# Altium schematic sheet Bluetooth+WiFi+LoRa.SchDoc
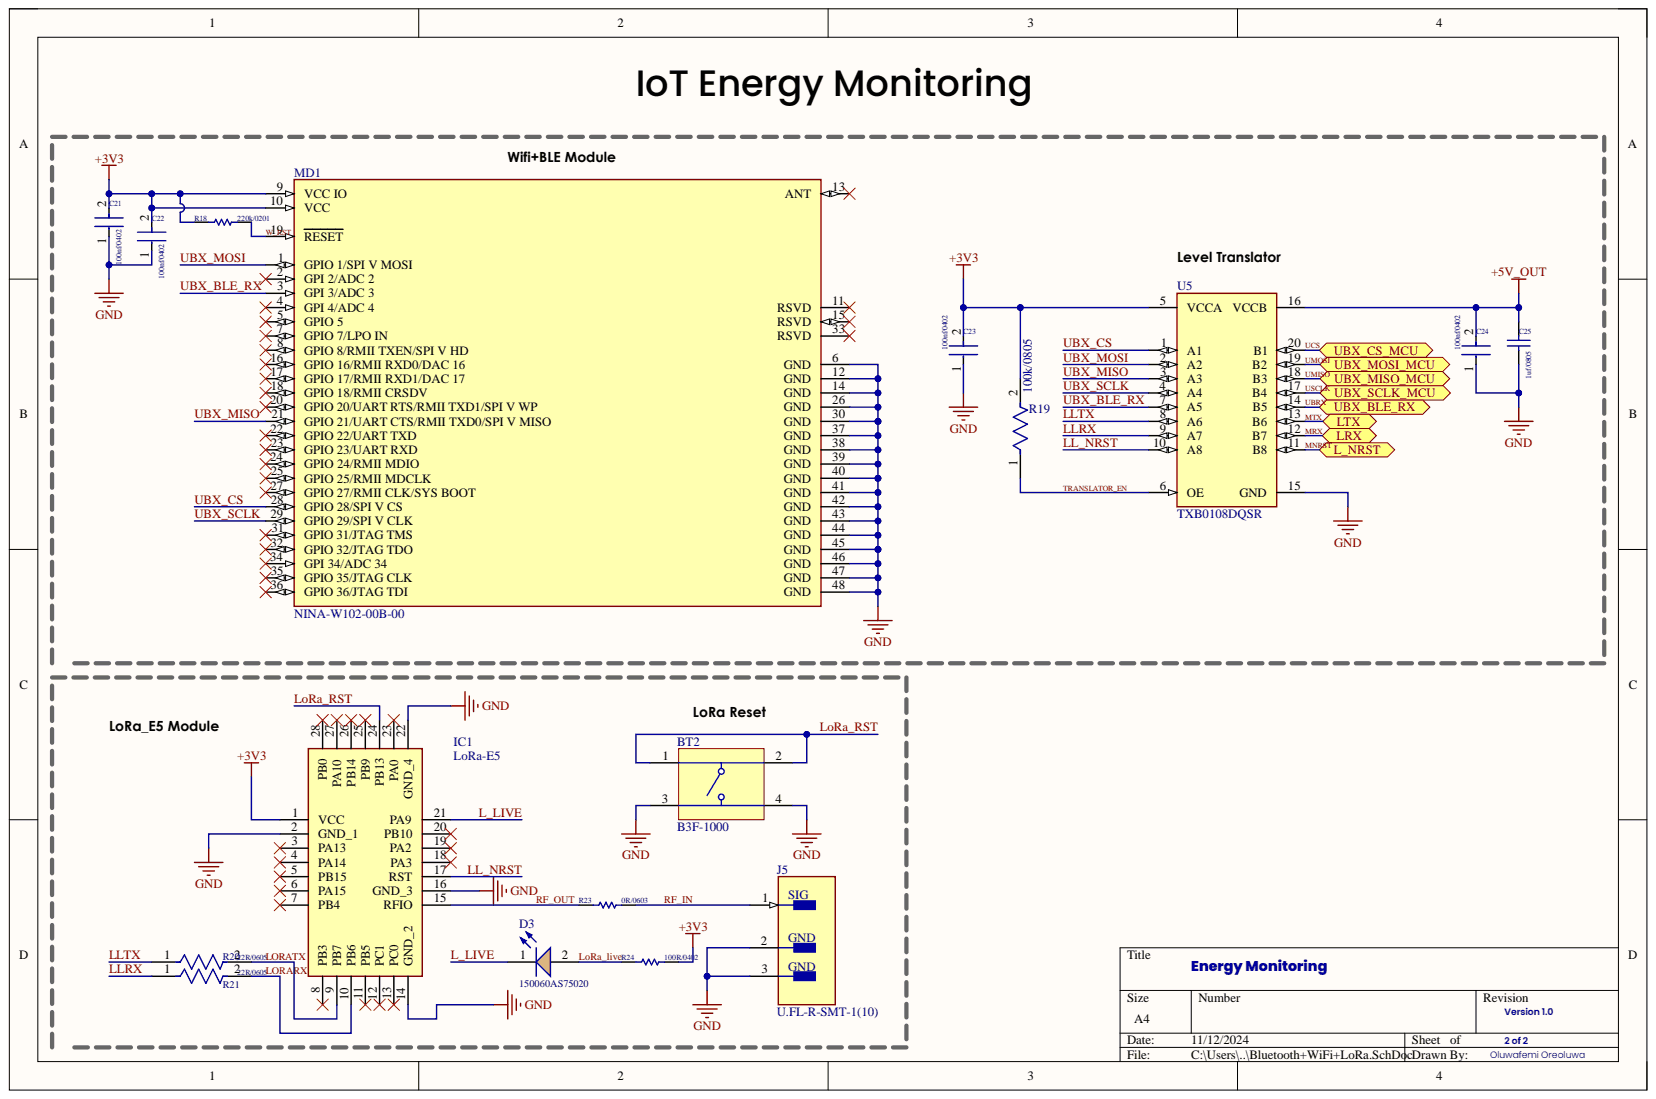
[diagram p0: Bluetooth+WiFi+LoRa.SchDoc]

## Parts
BT2  B3F-1000  [OMRON-B3F-1000-4_V]
C21  100nf/0402  [FP-GRM15-MFG]
C22  100nf/0402  [FP-GRM15-MFG]
C23  100nf/0402  [FP-GRM15-MFG]
C24  100nf/0402  [FP-GRM15-MFG]
C25  1uf/0805  [CAPC2013X140X50LL20T25]
D3  150060AS75020  [WL-SMCW_0603_150060xx75020]
IC1  LoRa-E5  [LoRaE5]
J5  U.FL-R-SMT-1(10)  [FP-U_FL-R-SMT-1_10-MFG]
MD1  NINA-W102-00B-00  [FP-NINA-W102-00B-00-MFG]
R18  220k/0201  [RESC0603X26X15ML03T05]
R19  100k/0805  [FP-RN732A-MFG]
R20  22R/0605  [FP-CPF0603-MFG]
R21  22R/0605  [FP-CPF0603-MFG]
R23  0R/0603  [RESC1608X55X25ML10T15]
R24  100R/0402  [RESC1005X40X25LL05T05]
U5  TXB0108DQSR  [FP-DQS0020A-MFG]

## Ports
L_NRST, LRX, LTX, UBX_BLE_RX, UBX_CS_MCU, UBX_MISO_MCU, UBX_MOSI_MCU, UBX_SCLK_MCU

## Nets
+3V3: C21.2 C22.2 C23.2 IC1.1(VCC) MD1.9(VCC_IO) MD1.10(VCC) R18.1 R19.2 R24.2 U5.5(VCCA)
+5V_OUT: C24.2 C25.2 U5.16(VCCB)
GND: BT2.3 BT2.4 C21.1 C22.1 C23.1 C24.1 C25.1 IC1.2(GND_1) IC1.14(GND_2) IC1.16(GND_3) IC1.22(GND_4) J5.2 J5.3 MD1.6(GND) MD1.12(GND) MD1.14(GND) MD1.26(GND) MD1.30(GND) MD1.37(GND) MD1.38(GND) MD1.39(GND) MD1.40(GND) MD1.41(GND) MD1.42(GND) MD1.43(GND) MD1.44(GND) MD1.45(GND) MD1.46(GND) MD1.47(GND) MD1.48(GND) U5.15(GND)
L_LIVE: D3.1 IC1.21(PA9)
LL_NRST: IC1.17(RST) U5.10(A8)
LLRX: R21.1 U5.9(A7)
LLTX: R20.1 U5.8(A6)
LoRa_live: D3.2 R24.1
LoRa_RST: BT2.1 BT2.2 IC1.24(PB13)
LORARX: IC1.10(PB6) R21.2
LORATX: IC1.9(PB7) R20.2
MNRST: U5.11(B8) ; port L_NRST
MRX: U5.12(B7) ; port LRX
MTX: U5.13(B6) ; port LTX
RF_IN: J5.1 R23.2
RF_OUT: IC1.15(RFIO) R23.1
TRANSLATOR_EN: R19.1 U5.6(OE)
UBRX: U5.14(B5) ; port UBX_BLE_RX
UBX_BLE_RX: MD1.3(GPI_3/ADC_3) U5.7(A5)
UBX_CS: MD1.28(GPIO_28/SPI_V_CS) U5.1(A1)
UBX_MISO: MD1.21(GPIO_21/UART_CTS/RMII_TXD0/SPI_V_MISO) U5.3(A3)
UBX_MOSI: MD1.1(GPIO_1/SPI_V_MOSI) U5.2(A2)
UBX_SCLK: MD1.29(GPIO_29/SPI_V_CLK) U5.4(A4)
UCS: U5.20(B1) ; port UBX_CS_MCU
UMISO: U5.18(B3) ; port UBX_MISO_MCU
UMOSI: U5.19(B2) ; port UBX_MOSI_MCU
USCLK: U5.17(B4) ; port UBX_SCLK_MCU
W_RST: MD1.19(RESET) R18.2

Not connected: IC1 3(PA13) 4(PA14) 5(PB15) 6(PA15) 7(PB4) 8(PB3) 11(PB5) 12(PC1) 13(PC0) 18(PA3) 19(PA2) 20(PB10) 23(PA0) 25(PB9) 26(PB14) 27(PA10) 28(PB0); MD1 2(GPI_2/ADC_2) 4(GPI_4/ADC_4) 5(GPIO_5) 7(GPIO_7/LPO_IN) 8(GPIO_8/RMII_TXEN/SPI_V_HD) 11(RSVD) 13(ANT) 15(RSVD) 16(GPIO_16/RMII_RXD0/DAC_16) 17(GPIO_17/RMII_RXD1/DAC_17) 18(GPIO_18/RMII_CRSDV) 20(GPIO_20/UART_RTS/RMII_TXD1/SPI_V_WP) 22(GPIO_22/UART_TXD) 23(GPIO_23/UART_RXD) 24(GPIO_24/RMII_MDIO) 25(GPIO_25/RMII_MDCLK) 27(GPIO_27/RMII_CLK/SYS_BOOT) 31(GPIO_31/JTAG_TMS) 32(GPIO_32/JTAG_TDO) 33(RSVD) 34(GPI_34/ADC_34) 35(GPIO_35/JTAG_CLK) 36(GPIO_36/JTAG_TDI)

## Library data of the parts
BT2 = CMP-1677-00002-1: Tactile Switch, SPST-NO, 0.05 A, 5 to 24 V, -25 to 70 degC, 4-Pin THD, RoHS, Bulk; Capacitance: -45 f; Current Rating: 1.5 A; Gender: Female; Shielding: Shielded; Voltage Rating: 150 V; Component Kind: Standard; ComponentLink1Description: Manufacturer URL; ComponentLink1URL: http://www.omron.com/; ComponentLink2Description: Datasheet; ComponentLink2URL: http://www.omron.com/ecb/products/pdf/en-b3f.pdf; Contact Material: Brass; Contact Plating: Gold; DatasheetVersion: Jan-15; Height: 14.99 mm; Lead Pitch: 1.27 mm; Length: 18.34 mm; Library Name: Altium Content Vault; Max Operating Temperature: 70 degC; Min Operating Temperature: -40 degC; Mount: Through Hole; Package Quantity: 1080; PackageDescription: 4-Pin Through Hole Device, Body 6 x 6 mm; PackageReference: B3F-1000; Packaging: Tray; Pins: 8; Radiation Hardening: No; RoHSCompliant: true; Width: 25.4 mm
C21-C24 = CMP-2100-03641-2: CAP CER 0.1UF 16V X7R 0402; Manufacturer: Murata; Manufacturer Part Number: GRM155R71C104KA88D; Capacitance: 0.10μF; Material: Ceramic; Standoff Height: 0mm; Tolerance: 10%; Value: 0.10μF; Voltage Rating: 16Vdc; Category: Cap; Component Kind: Standard; ComponentLink2Description: Datasheet; ComponentLink2URL: https://search.murata.co.jp/Ceramy/image/img/A01X/G101/ENG/GRM155R71H471KA01-01A.pdf; Device Class L1: Passive Components; Device Class L2: Capacitors; Device Class L3: Ceramic Capacitors; Digikey Description: CAP CER 0.1UF 16V X7R 0402; Height: 0.55mm; Ipc Land Pattern Name: CAPC10050X219; Lead Free: yes; Library Name: Altium Content Vault; Mouser Description: 0402 0.1 uF 16V ±10 % Tolerance X7R SMT Multilayer Ceramic Capacitor; Octopart: https://octopart.com/grm155r71c104ka88d-murata-196880; Package: GRM15; RoHS: TRUE; Temperature: -55°C to +125°C; Temperature Characteristic: X7R; Temperature Range High: +125°C; Temperature Range Low: -55°C
C25 = CMP-2007-03234-1: Manufacturer: Kemet; Part Number: C0805C105K3RACTU; Component Kind: Standard; Library Name: Altium Content Vault
D3 = CMP-03913-104891423-2: WL-SMCW SMT Mono-Color Chip LED Waterclear super f; Dominant Wavelength: 605nm; Forward Current: 60mA; Forward Voltage: 2V; Luminous Intensity: 140mcd; Max Reverse Voltage (DC): 5V; Peak Wavelength: 610nm; Viewing Angle: 140°; Component Kind: Standard; Height: 250µm; Length: 1.6mm; Library Name: Altium Content Vault; Max Operating Temperature: 85°C; Min Operating Temperature: -40°C; RoHS: Compliant; Width: 800µm
IC1 = LoRa-E5: Integrated Circuit; Manufacturer_Name: Seeed Studio; Component Kind: Standard; Datasheet Link: https://no.mouser.com/datasheet/2/744/LoRa_E5_module_datasheet_V1_0-2488126.pdf; Height: 2.5mm; Manufacturer_Part_Number: LoRa-E5
J5 = CMP-2000-05152-3: CONN U.FL RCPT STR 50 OHM SMD; Body Material: Bronze; Body Plating: Silver; Connector Type: Coaxial; Dielectric Material: Polymer; Frequency: 6GHz; Gender: Male; Impedance: 50R; Insulation Resistance: 500MR; Component Kind: Standard; Contact Material: Brass; Contact Plating: Gold; Depth: 3.1mm; Fastening Type: Snap; Housing Color: Beige; Lead Free: Lead Free; Length: 3mm; Library Name: Altium Content Vault; Max Frequency: 6GHz; Max Operating Temperature: 90°C; Min Operating Temperature: -40°C; Mount: Surface Mount; Orientation: Straight; Package Quantity: 2500; Packaging: Cut Tape; Radiation Hardening: No; REACH SVHC: No SVHC; Series: U.FL; Termination: Solder; Weight: 0.001764oz; Wire/Cable Type: Coaxial
MD1 = CMP-207130-000007-1: STAND-ALONE MULTIRADIO MODULES; Manufacturer: U-Blox; Bandwidth: 20MHz; Data Rate: 100Mbits/s; Interface: I2C,SPI,UART,CAN,Ethernet,I2S,Other; Max Frequency: 2.5GHz; Max Supply Voltage: 3.6V; Min Supply Voltage: 3V; Nominal Supply Current: 30-190mA; Number Of Adc Channels: 17; Number Of Gpio: 20; Receiving Current: 100-140mA; Sensitivity: -96dBm; Transmitting Current: 225-320mA; Automotive: No; Category: UNK; Component Kind: Standard; ComponentLink2Description: Datasheet; ComponentLink2URL: https://www.u-blox.com/sites/default/files/NINA-W10_DataSheet_%28UBX-17065507%29.pdf; Device Class L1: Integrated Circuits (ICs); Device Class L2: RF Semiconductors and Devices; Device Class L3: Transceivers; Digikey Description: STAND-ALONE MULTIRADIO MODULES; Height: 4.2mm; Lead Free: Yes; Library Name: Altium Content Vault; Manufacturer Part Number: NINA-W102-00B-00; Octopart: https://octopart.com/nina-w102-00b-00-u-blox-103157672; Package: 0; RoHS: TRUE; Temperature: -40°C to +85°C; Temperature Range High: +85°C; Temperature Range Low: -40°C
R18 = CMP-2004-03487-1: Manufacturer: Panasonic; Part Number: ERJ1GNF2203C; Component Kind: Standard; Library Name: Altium Content Vault
R19 = CMP-03211-002801-1: SMD Chip Resistor, Thin Film, 100KΩ, 100 V, 0805 [2012 Metric], 100 mW, 0.1%, RN73 Series; Manufacturer: TE Connectivity; Manufacturer Part Number: RN73C2A100KBTDF; Power Rating: 0.1W; Temperature Coefficient: 10ppm/°C; Voltage Rating: 100V; Automotive: No; Category: Res; Component Kind: Standard; ComponentLink2Description: Datasheet; ComponentLink2URL: https://www.te.com/commerce/DocumentDelivery/DDEController?Action=showdoc&DocId=Data+Sheet%7F1773270%7FP%7Fpdf%7FEnglish%7FENG_DS_1773270_P.pdf%7F1676162-2; Composition: Thin Film; Device Class L1: Passive Components; Device Class L2: Resistors; Device Class L3: Chip SMD Resistors; Height: 0.65mm; Ipc Land Pattern Name: RESC200125X55; Lead Free: Yes; Library Name: Altium Content Vault; Octopart: https://octopart.com/rn73c2a100kbtdf-te+connectivity-21411916; octopart_url: https://octopart.com/rn73c2a100kbtdf-te+connectivity-21411916; Package: RN732A; packaging: 1000 Reel; Resistance: 100KΩ; RoHS: TRUE; Series: RN73; Temperature: -55°C to 155°C; Temperature Range High: 155°C; Temperature Range Low: -55°C; Tolerance: 0.1%; Value: 100KΩ
R20 R21 = CMP-03211-007811-1: RES SMD 22Ω 0.1% 1/16W 0603; Manufacturer: TE Connectivity; Manufacturer Part Number: CPF0603B22RE1; Power Rating: 0.063W; Temperature Coefficient: 25ppm/°C; Voltage Rating: 50V; Automotive: No; Category: Res; Component Kind: Standard; ComponentLink2Description: Datasheet; ComponentLink2URL: https://www.te.com/commerce/DocumentDelivery/DDEController?Action=showdoc&DocId=Data+Sheet%7F1773200%7FM%7Fpdf%7FEnglish%7FENG_DS_1773200_M.pdf%7F3-1676481-2; Composition: Thin Film; Device Class L1: Passive Components; Device Class L2: Resistors; Device Class L3: Chip SMD Resistors; Height: 0.55mm; Ipc Land Pattern Name: RESC15580X45; Lead Free: Yes; Library Name: Altium Content Vault; Octopart: https://octopart.com/cpf0603b22re1-te+connectivity-9539398; octopart_url: https://octopart.com/cpf0603b22re1-te+connectivity-9539398; Package: CPF0603; packaging: 1k Reel; Resistance: 22Ω; RoHS: TRUE; Series: CPF; Temperature: -55°C to +155°C; Temperature Range High: +155°C; Temperature Range Low: -55°C; Tolerance: 0.1%; Value: 22Ω
R23 = CMP-2100-03667-1: Chip Resistor, 0 Ohm, +/- 5%, 0.1 W, -55 to 155 degC, 0603 (1608 Metric), RoHS, Tape and Reel; Manufacturer: Yageo; Power Rating: 100mW; Resistance: 0mOhm; Temperature Coefficient: 200ppm/degreesC; Voltage Rating: 50V; Case Code (Imperial): 0603; Case Code (Metric): 1608; Case/Package: 0603; Component Kind: Standard; Composition: ThickFilm; Contact Plating: Tin; Datasheet URL: http://www.yageo.com/NewPortal/yageodocoutput?fileName=/pdf/R-Chip/PYu-RC_Group_51_RoHS_L_5.pdf; Datasheet Version: Rev. 5, 10/2016; Depth: 0.8mm; Height: 0.45mm; Lead Free: LeadFree; Length: 1.6mm; Library Name: Altium Content Vault; Manufacturer URL: http://www.yageo.com/; Max Operating Temperature: 155degC; Military Standard: Not; Min Operating Temperature: -55degC; Number of Pins: 2; Package Description: 2-Pin Surface Mount Device, Body 1.6 x 0.8 mm; Package Quantity: 5000; Package Reference: 0603; Packaging: TapeandReel; Radiation Hardening: No; REACH SVHC: NoSVHC; RoHSCompliant: true; Termination: SMD/SMT; Tolerance: 5%; Voltage Rating (DC): 50V; Width: 0.8mm
R24 = CMP-2002-00605-3: Chip Resistor, 100 Ohm, +/- 1%, 100 mW, -55 to 155 degC, 0402 (1005 Metric), RoHS, Tape and Reel; Manufacturer: Basic Passives; PartNumber: ERJ-2RKF1000X; Case\/Package: 0402; Power Rating: 100 mW; Resistance: 100 Ohm; Voltage Rating: 50 V; Case Code (Imperial): 0402; Case Code (Metric): 1005; Component Kind: Standard; ComponentLink1Description: Manufacturer URL; ComponentLink1URL: http://www.panasonic.com/; ComponentLink2Description: Datasheet; ComponentLink2URL: http://industrial.panasonic.com/cdbs/www-data/pdf/RDA0000/AOA0000C86.pdf; DatasheetVersion: 04/2015; Height: 0.35 mm; Length: 1 mm; Library Name: Altium Content Vault; Max Operating Temperature: 155 degC; Min Operating Temperature: -55 degC; Mount: Surface Mount; Mounting Technology: Surface Mount; Package Quantity: 10000; PackageDescription: 2-Pin Surface Mount Device, Body 1 x 0.5 mm; PackageReference: 0402; Packaging: Tape and Reel; Pins: 2; Radiation Hardening: No; REACH SVHC: Yes; RoHSCompliant: true; Tolerance: 1%; Width: 0.5 mm
U5 = CMP-04899-000009-1: IC TRNSLTR BIDIRECTIONAL 20USON; Manufacturer: Texas Instruments; Data Rate: 100Mbits/s; Logic Function Desc: Non-Inverting; Max Junction Temp: +150°C; Max Supply Voltage: 5.5V; Min Supply Voltage: 1.2V; Nominal Supply Current: 0.05-3.5uA; Number Of Circuits: 8; Number Of Inputs: 1; Propagation Delay: 4ns; Standoff Height: 0mm; V A Max: 3.6V; V B Min: 1.65V; Ambient Temperature Range High: +85°C; Ambient Temperature Range Low: -40°C; Automotive: No; Category: IC; Component Kind: Standard; ComponentLink2Description: Datasheet; ComponentLink2URL: https://www.ti.com/lit/ds/symlink/txb0108.pdf; Device Class L1: Integrated Circuits (ICs); Device Class L2: Logic ICs; Device Class L3: Voltage Level Shifters; Digikey Description: IC TRNSLTR BIDIRECTIONAL 20USON; Height: 0.55mm; Lead Free: Yes; Library Name: Altium Content Vault; Manufacturer Part Number: TXB0108DQSR; Mouser Description: Translation - Voltage Levels 8B Bidir Vltg-Level Translator; Number Of Outputs: 1; Octopart: https://octopart.com/txb0108dqsr-texas+instruments-18562890; Package: DQS0020A; RoHS: TRUE; Temperature: -40°C to +85°C; Temperature Range High: +85°C; Temperature Range Low: -40°C; V A Min: 1.2V; V B Max: 5.5V
